# Altium schematic sheet eps_bb2.SchDoc
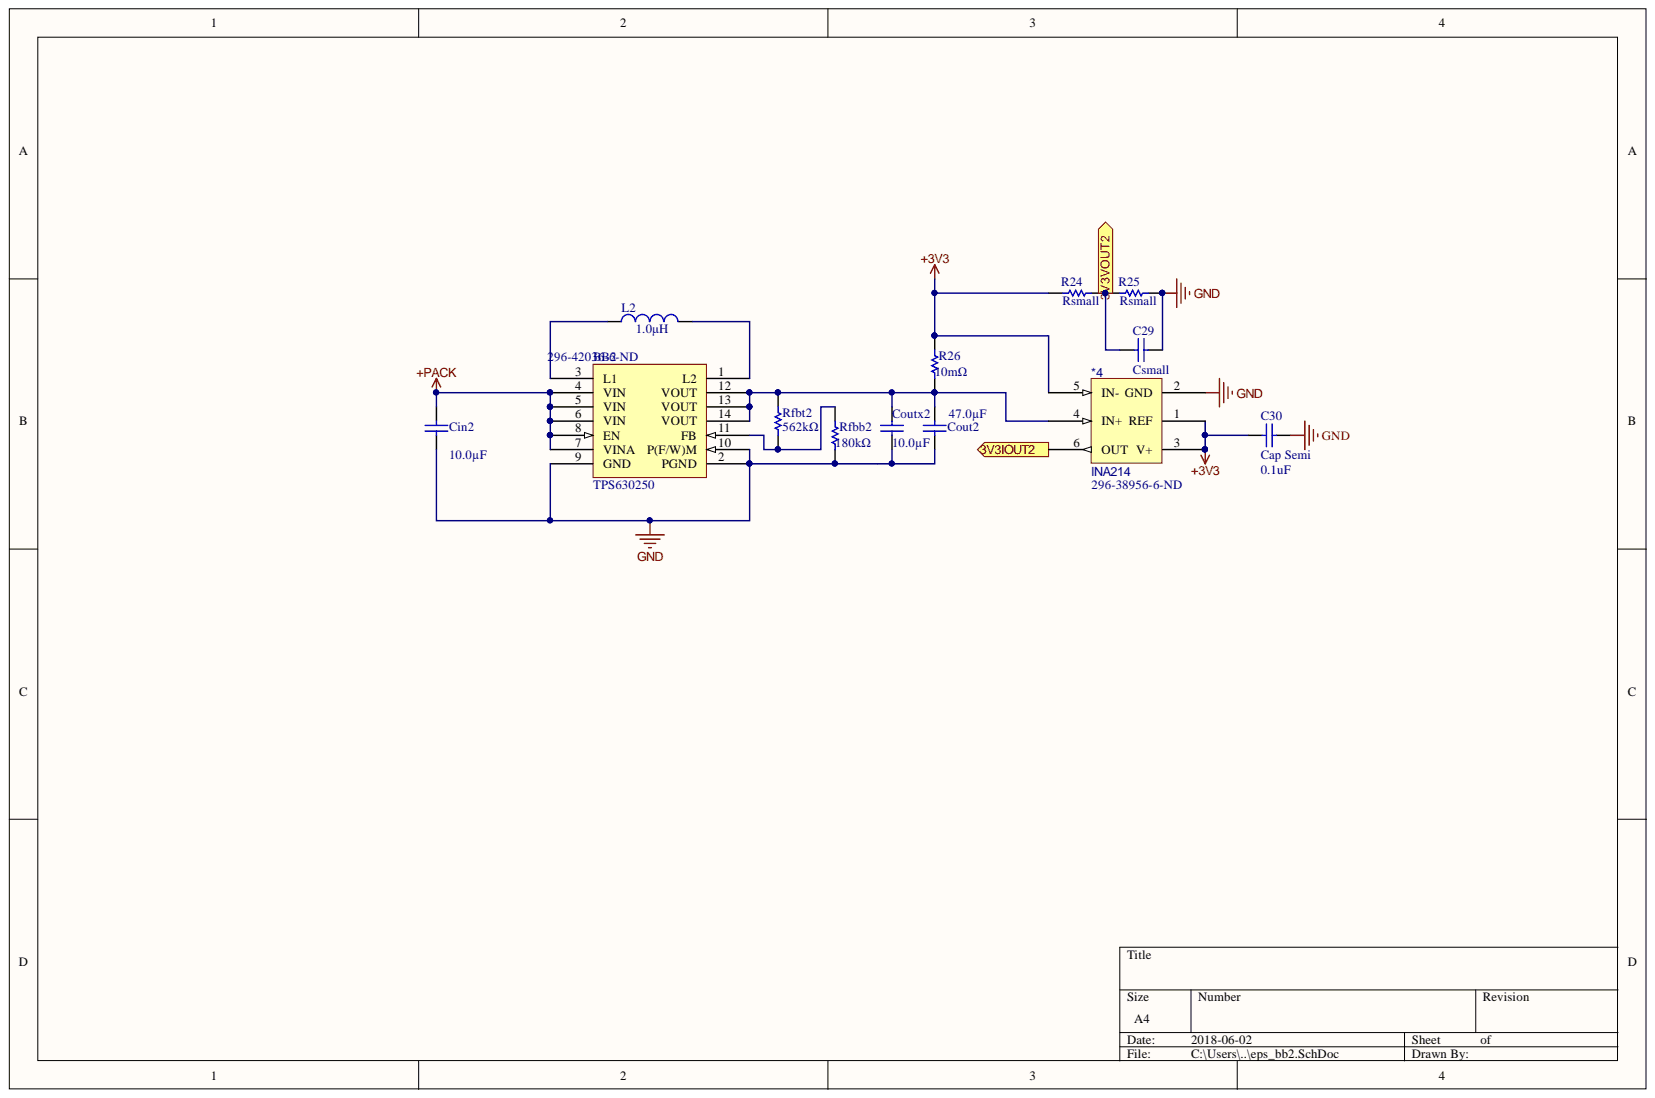
[diagram p0: eps_bb2.SchDoc]

## Parts
*4  INA214  Supplier Part Number 1: 296-38956-6-ND  [SOT65P210X110-6N]
BB2  TPS630250  Supplier Part Number 1: 296-42036-6-ND  [RNC0014A]
C29  value Csmall  [1608[0603]]  (hidden comment: Cap)
C30  Cap Semi  value 0.1uF  [1608[0603]]
Cin2  value 10.0µF  [1608[0603]]  (hidden comment: Cap)
Cout2  value 47.0µF  [1608[0603]]  (hidden comment: Cap)
Coutx2  value 10.0µF  [1608[0603]]  (hidden comment: Cap)
L2  value 1.0μH  [1608[0603]]  (hidden comment: Inductor)
R24  value Rsmall  [1608[0603]]  (hidden comment: Res1)
R25  value Rsmall  [1608[0603]]  (hidden comment: Res1)
R26  value 10mΩ  [1608[0603]]  (hidden comment: Res1)
Rfbb2  value 180kΩ  [1608[0603]]  (hidden comment: Res1)
Rfbt2  value 562kΩ  [1608[0603]]  (hidden comment: Rfbt1)

## Ports
3V3IOUT2, 3V3VOUT2

## Nets
+3V3: *4.1(REF) *4.3(V+) *4.5(IN-) C30.2 R24.1 R26.2
+PACK: BB2.4(VIN) BB2.5(VIN) BB2.6(VIN) BB2.7(VINA) BB2.8(EN) Cin2.2
GND: *4.2(GND) BB2.2(PGND) BB2.9(GND) BB2.10(P(F/W)M) C29.1 C30.1 Cin2.1 Cout2.1 Coutx2.1 R25.2 Rfbb2.1
3V3IOUT2: *4.6(OUT) ; port 3V3IOUT2
3V3VOUT2: C29.2 R24.2 R25.1 ; port 3V3VOUT2
Net*4_4: *4.4(IN+) BB2.12(VOUT) BB2.13(VOUT) BB2.14(VOUT) Cout2.2 Coutx2.2 R26.1 Rfbt2.1
NetBB2_1: BB2.1(L2) L2.2
NetBB2_3: BB2.3(L1) L2.1
NetBB2_11: BB2.11(FB) Rfbb2.2 Rfbt2.2

## Library data of the parts
*4 = INA214: Current monitor; Component Kind: Standard; Supplier 1: Digi-Key; Library Name: heron-general.IntLib
BB2 = TPS630250: Buck-boost converter; Component Kind: Standard; Supplier 1: Digi-Key; Library Name: heron-general.IntLib
C29 Cin2 Cout2 Coutx2 = Cap: Capacitor; Component Kind: Standard; LatestRevisionDate: 17-Jul-2002; LatestRevisionNote: Re-released for DXP Platform.; Library Name: Miscellaneous Devices.IntLib; PackageDescription: Radial Cap, Thru-Hole; 2 Leads; 0.3 in Pin Spacing; PackageReference: RAD-0.3; Published: 8-Jun-2000; Signal Integrity: Cap; Simulation: CAP; Publisher: Altium Limited
C30 = Cap Semi: Capacitor (Semiconductor SIM Model); Component Kind: Standard; LatestRevisionDate: 29-May-2009; LatestRevisionNote: IPC-7351 Footprint Added.; Library Name: Miscellaneous Devices.IntLib; PackageDescription: Chip Capacitor; PackageReference: C1206; Published: 8-Jun-2000; Signal Integrity: Cap Semi; Simulation: CAP; Publisher: Altium Limited
L2 = Inductor: Code_IEC: 1005; Code_JEITA: 0402; Component Kind: Standard; Description: Inductor; LatestRevisionDate: 29-May-2009; LatestRevisionNote: IPC-7351 Footprint Added.; Library Name: Miscellaneous Devices.IntLib; PackageDescription: Chip Inductor; PackageReference: 0402-A; Published: 8-Jun-2000; Signal Integrity: Inductor; Simulation: INDUCTOR; Publisher: Altium Limited
R24-R26 Rfbb2 Rfbt2 = Res1: Resistor; Component Kind: Standard; LatestRevisionDate: 17-Jul-2002; LatestRevisionNote: Re-released for DXP Platform.; Library Name: Miscellaneous Devices.IntLib; PackageDescription: Axial Device, Thru-Hole; 2 Leads; 0.3 in Pin Spacing; PackageReference: AXIAL-0.3; Published: 8-Jun-2000; Signal Integrity: Res; Simulation: RESISTOR; Publisher: Altium Limited
